# Altium schematic sheet MCU_Interface.SchDoc(MCU_Interface)
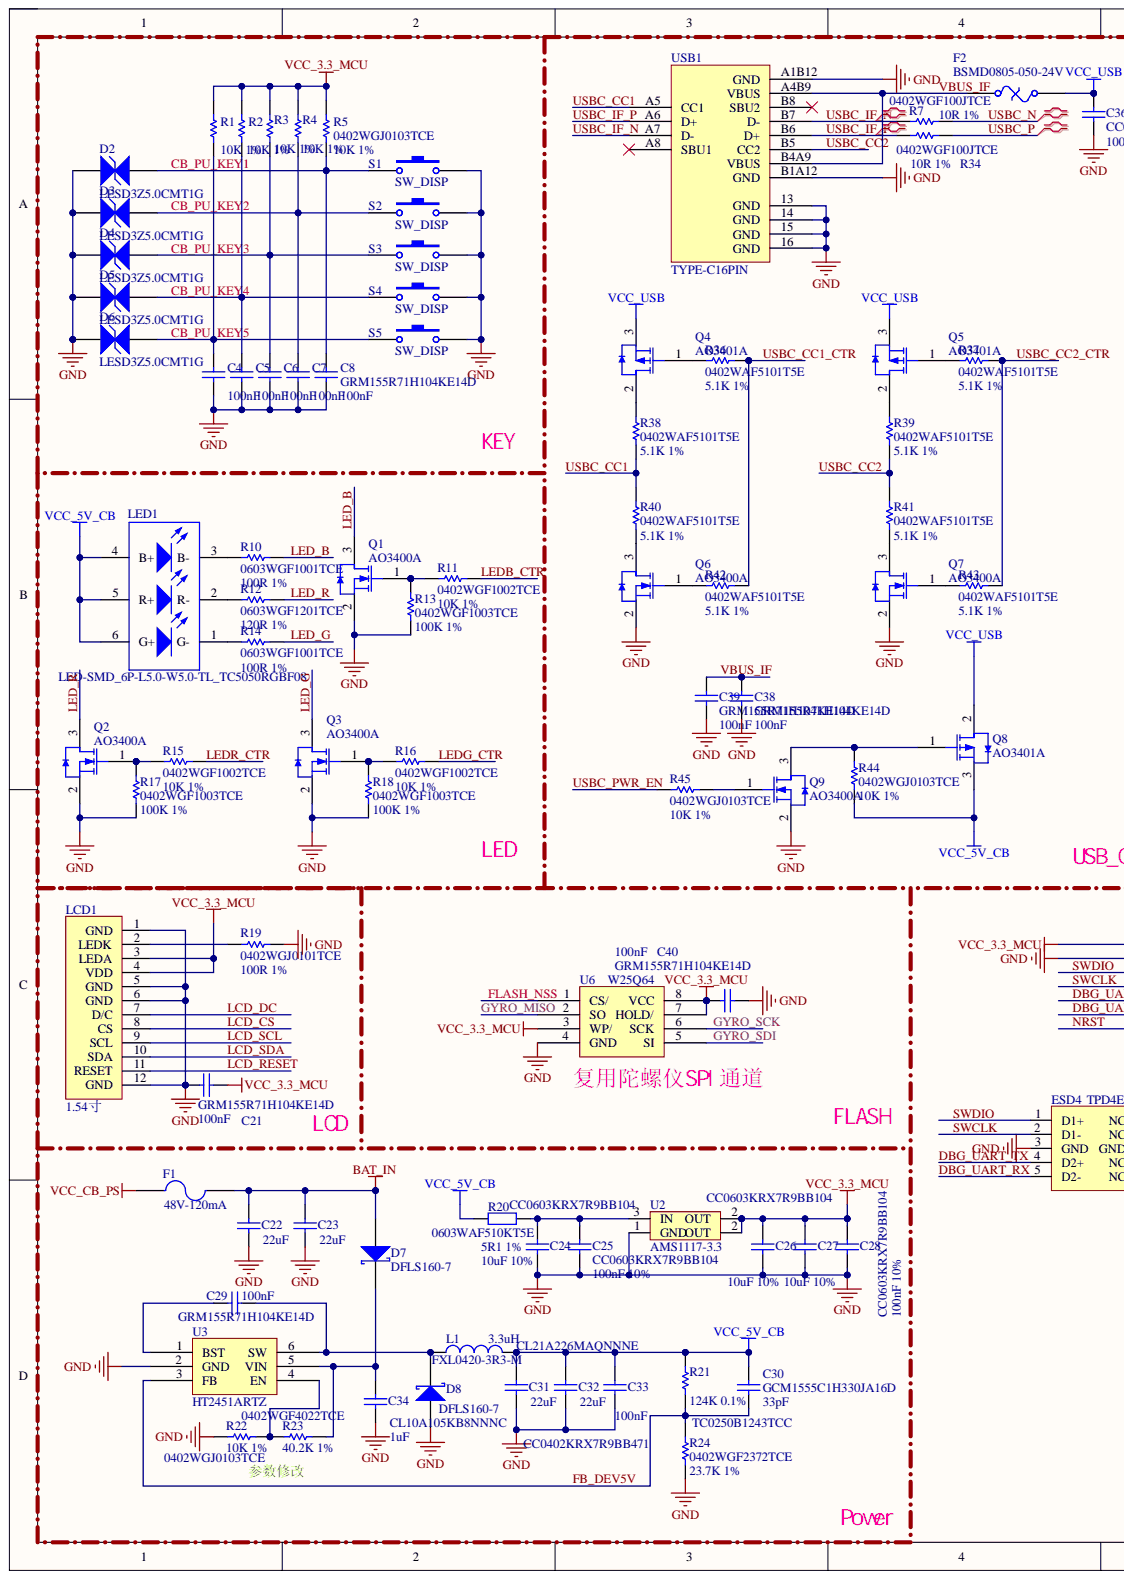
[diagram p0: MCU_Interface.SchDoc, left]
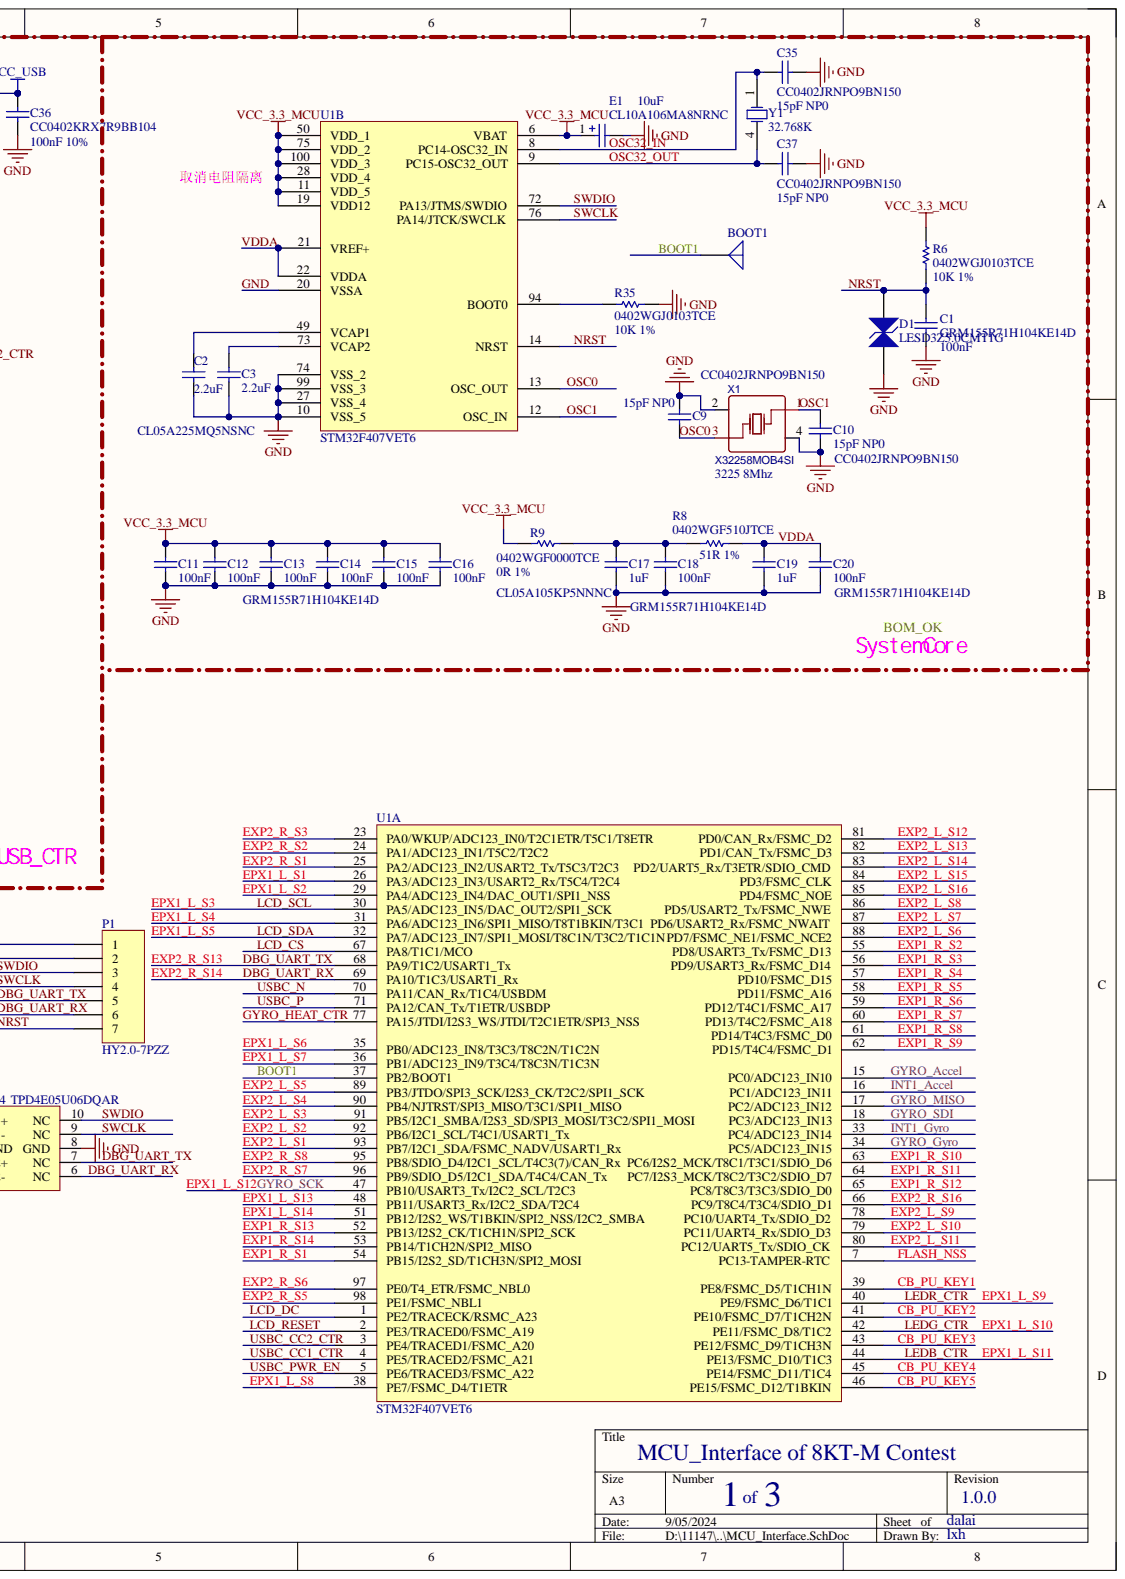
[diagram p1: MCU_Interface.SchDoc, right]

## Parts
BOOT1  Testpoint  [TP_1*1]  (value not drawn)
C1  GRM155R71H104KE14D  value 100nF  [0402_C_3D]
C2  CL05A225MQ5NSNC  value 2.2uF  [0402_C_3D]
C3  CL05A225MQ5NSNC  value 2.2uF  [0402_C_3D]
C4  GRM155R71H104KE14D  value 100nF  [0402_C_3D]
C5  GRM155R71H104KE14D  value 100nF  [0402_C_3D]
C6  GRM155R71H104KE14D  value 100nF  [0402_C_3D]
C7  GRM155R71H104KE14D  value 100nF  [0402_C_3D]
C8  GRM155R71H104KE14D  value 100nF  [0402_C_3D]
C9  CC0402JRNPO9BN150  value 15pF NP0  [0402_C_3D]
C10  CC0402JRNPO9BN150  value 15pF NP0  [0402_C_3D]
C11  GRM155R71H104KE14D  value 100nF  [0402_C_3D]
C12  GRM155R71H104KE14D  value 100nF  [0402_C_3D]
C13  GRM155R71H104KE14D  value 100nF  [0402_C_3D]
C14  GRM155R71H104KE14D  value 100nF  [0402_C_3D]
C15  GRM155R71H104KE14D  value 100nF  [0402_C_3D]
C16  GRM155R71H104KE14D  value 100nF  [0402_C_3D]
C17  CL05A105KP5NNNC  value 1uF  [0402_C_3D]
C18  GRM155R71H104KE14D  value 100nF  [0402_C_3D]
C19  CL05A105KP5NNNC  value 1uF  [0402_C_3D]
C20  GRM155R71H104KE14D  value 100nF  [0402_C_3D]
C21  GRM155R71H104KE14D  value 100nF  [0402_C_3D]
C22  CL21A226MAQNNNE  value 22uF  [0805_C_3D]
C23  CL21A226MAQNNNE  value 22uF  [0805_C_3D]
C24  CC0603KRX7R9BB104  value 10uF 10%  [0603_C_3D]
C25  CC0603KRX7R9BB104  value 100nF 10%  [0603_C_3D]
C26  CC0603KRX7R9BB104  value 10uF 10%  [0603_C_3D]
C27  CC0603KRX7R9BB104  value 10uF 10%  [0603_C_3D]
C28  CC0603KRX7R9BB104  value 100nF 10%  [0603_C_3D]
C29  GRM155R71H104KE14D  value 100nF  [0603_C_3D]
C30  GCM1555C1H330JA16D  value 33pF  [0402_C_3D]
C31  CL21A226MAQNNNE  value 22uF  [0805_C_3D]
C32  CL21A226MAQNNNE  value 22uF  [0805_C_3D]
C33  CC0402KRX7R9BB471  value 100nF  [0603_C_3D]
C34  CL10A105KB8NNNC  value 1uF  [0603_C_3D]
C35  CC0402JRNPO9BN150  value 15pF NP0  [0402_C_3D]
C36  CC0402KRX7R9BB104  value 100nF 10%  [0402_C_3D]
C37  CC0402JRNPO9BN150  value 15pF NP0  [0402_C_3D]
C38  GRM155R71H104KE14D  value 100nF  [0402_C_3D]
C39  GRM155R71H104KE14D  value 100nF  [0402_C_3D]
C40  GRM155R71H104KE14D  value 100nF  [0402_C_3D]
D1  LESD3Z5.0CMT1G  [SOD-323_L1.8-W1.3]
D2  LESD3Z5.0CMT1G  [SOD-323_L1.8-W1.3]
D3  LESD3Z5.0CMT1G  [SOD-323_L1.8-W1.3]
D4  LESD3Z5.0CMT1G  [SOD-323_L1.8-W1.3]
D5  LESD3Z5.0CMT1G  [SOD-323_L1.8-W1.3]
D6  LESD3Z5.0CMT1G  [SOD-323_L1.8-W1.3]
D7  DFLS160-7  [SOD-123_L2.8-W1.8]
D8  DFLS160-7  [SOD-123_L2.8-W1.8]
E1  CL10A106MA8NRNC  value 10uF  [0603_C_3D]
ESD4  TPD4E05U06DQAR  [USON-10_L2.5-W1.0-P0.50-BL]
F1  48V-120mA  [1206_R]
F2  BSMD0805-050-24V  [0805_R_3D]
L1  FXL0420-3R3-M  value 3.3uH  [IND-SMD_L7.0-W6.6]
LCD1  1.54寸  [1.54" OBE_IPS LCD]
LED1  LED-SMD_6P-L5.0-W5.0-TL_TC5050RGBF08  [LED-SMD_6P-L5.0-W5.0-TL_TC5050RGBF08]
P1  HY2.0-7PZZ  [CONN-TH_7P-P2.00_ZX-HY2.0-7PZZ]
Q1  AO3400A  [SOT-23-3D]
Q2  AO3400A  [SOT-23-3D]
Q3  AO3400A  [SOT-23-3D]
Q4  AO3401A  [SOT-23-3D]
Q5  AO3401A  [SOT-23-3D]
Q6  AO3400A  [SOT-23-3D]
Q7  AO3400A  [SOT-23-3D]
Q8  AO3401A  [SOT-23-3D]
Q9  AO3400A  [SOT-23-3D]
R1  0402WGJ0103TCE  value 10K 1%  [0402_R_3D]
R2  0402WGJ0103TCE  value 10K 1%  [0402_R_3D]
R3  0402WGJ0103TCE  value 10K 1%  [0402_R_3D]
R4  0402WGJ0103TCE  value 10K 1%  [0402_R_3D]
R5  0402WGJ0103TCE  value 10K 1%  [0402_R_3D]
R6  0402WGJ0103TCE  value 10K 1%  [0402_R_3D]
R7  0402WGF100JTCE  value 10R 1%  [0402_R_3D]
R8  0402WGF510JTCE  value 51R 1%  [0402_R_3D]
R9  0402WGF0000TCE  value 0R 1%  [0402_R_3D]
R10  0603WGF1001TCE  value 100R 1%  [0603_R_3D]
R11  0402WGF1002TCE  value 10K 1%  [0402_R_3D]
R12  0603WGF1201TCE  value 120R 1%  [0603_R_3D]
R13  0402WGF1003TCE  value 100K 1%  [0402_R_3D]
R14  0603WGF1001TCE  value 100R 1%  [0603_R_3D]
R15  0402WGF1002TCE  value 10K 1%  [0402_R_3D]
R16  0402WGF1002TCE  value 10K 1%  [0402_R_3D]
R17  0402WGF1003TCE  value 100K 1%  [0402_R_3D]
R18  0402WGF1003TCE  value 100K 1%  [0402_R_3D]
R19  0402WGJ0101TCE  value 100R 1%  [0402_R_3D]
R20  0603WAF510KT5E  value 5R1 1%  [0603_R_3D]
R21  TC0250B1243TCC  value 124K 0.1%  [0402_R_3D]
R22  0402WGJ0103TCE  value 10K 1%  [0402_R_3D]
R23  0402WGF4022TCE  value 40.2K 1%  [0402_R_3D]
R24  0402WGF2372TCE  value 23.7K 1%  [0402_R_3D]
R34  0402WGF100JTCE  value 10R 1%  [0402_R_3D]
R35  0402WGJ0103TCE  value 10K 1%  [0402_R_3D]
R36  0402WAF5101T5E  value 5.1K 1%  [0402_R_3D]
R37  0402WAF5101T5E  value 5.1K 1%  [0402_R_3D]
R38  0402WAF5101T5E  value 5.1K 1%  [0402_R_3D]
R39  0402WAF5101T5E  value 5.1K 1%  [0402_R_3D]
R40  0402WAF5101T5E  value 5.1K 1%  [0402_R_3D]
R41  0402WAF5101T5E  value 5.1K 1%  [0402_R_3D]
R42  0402WAF5101T5E  value 5.1K 1%  [0402_R_3D]
R43  0402WAF5101T5E  value 5.1K 1%  [0402_R_3D]
R44  0402WGJ0103TCE  value 10K 1%  [0402_R_3D]
R45  0402WGJ0103TCE  value 10K 1%  [0402_R_3D]
S1  SW_DISP  [KEY-SMD_4P-L4.2-W3.2-P2.20-LS4.6]
S2  SW_DISP  [KEY-SMD_4P-L4.2-W3.2-P2.20-LS4.6]
S3  SW_DISP  [KEY-SMD_4P-L4.2-W3.2-P2.20-LS4.6]
S4  SW_DISP  [KEY-SMD_4P-L4.2-W3.2-P2.20-LS4.6]
S5  SW_DISP  [KEY-SMD_4P-L4.2-W3.2-P2.20-LS4.6]
U1  STM32F407VET6  [LQFP-100_L14.0-W14.0-P0.50-LS16.0-BL]  (parts A,B)
U2  AMS1117-3.3  [SOT-89-3_L4.5-W2.5-P1.50_3D]
U3  HT2451ARTZ  [SOT-23-6_L2.9-W1.6-P0.95_3D]
U6  W25Q64  [WSOP-8_L5.3-W5.3-P1.27-LS8.0-BL]
USB1  TYPE-C16PIN  [USB-C-SMD_TYPEC-304J-BCP16]
X1  X32258MOB4SI  value 3225 8Mhz  [SMD-3225_3D]
Y1  32.768K  [OSC-SMD_4P-L8.7-W3.7-BL]

## Nets
BAT_IN: C22.1 C23.1 D7.1 F1.2
VCC_3.3_MCU: C11.1 C12.1 C13.1 C14.1 C15.1 C16.1 C21.1 C26.1 C27.1 C28.1 C40.2 E1.1 LCD1.3(LEDA) LCD1.4(VDD) P1.1 R1.2 R2.2 R3.1 R4.1 R5.2 R6.2 R9.2 U1.6(VBAT) U1.11(VDD_5) U1.19(VDD12) U1.28(VDD_4) U1.50(VDD_1) U1.75(VDD_2) U1.100(VDD_3) U2.2 U6.3(WP/) U6.7(HOLD/) U6.8(VCC)
VCC_5V_CB: C30.2 C31.1 C32.1 C33.2 L1.2 LED1.4(B+) LED1.5(R+) LED1.6(G+) Q8.3 R20.1 R21.2 R44.2
VCC_CB_PS: F1.1
VCC_USB: C36.2 F2.2 Q4.3 Q5.3 Q8.2
BOOT1: BOOT1.1 U1.37(PB2/BOOT1)
CB_PU_KEY1: C8.2 D2.1 R5.1 S1.1 U1.39(PE8/FSMC_D5/T1CH1N)
CB_PU_KEY2: C7.2 D3.1 R4.2 S2.1 U1.41(PE10/FSMC_D7/T1CH2N)
CB_PU_KEY3: C6.2 D4.1 R3.2 S3.1 U1.43(PE12/FSMC_D9/T1CH3N)
CB_PU_KEY4: C5.2 D5.1 R2.1 S4.1 U1.45(PE14/FSMC_D11/T1C4)
CB_PU_KEY5: C4.2 D6.1 R1.1 S5.1 U1.46(PE15/FSMC_D12/T1BKIN)
DBG_UART_RX: ESD4.5(D2-) ESD4.6(NC) P1.6 U1.69(PA10/T1C3/USART1_Rx)
DBG_UART_TX: ESD4.4(D2+) ESD4.7(NC) P1.5 U1.68(PA9/T1C2/USART1_Tx)
EPX1_L_S1: U1.26(PA3/ADC123_IN3/USART2_Rx/T5C4/T2C4)
EPX1_L_S2: U1.29(PA4/ADC123_IN4/DAC_OUT1/SPI1_NSS)
EPX1_L_S3: LCD1.9(SCL) U1.30(PA5/ADC123_IN5/DAC_OUT2/SPI1_SCK)
EPX1_L_S4: U1.31(PA6/ADC123_IN6/SPI1_MISO/T8T1BKIN/T3C1)
EPX1_L_S5: LCD1.10(SDA) U1.32(PA7/ADC123_IN7/SPI1_MOSI/T8C1N/T3C2/T1C1N)
EPX1_L_S6: U1.35(PB0/ADC123_IN8/T3C3/T8C2N/T1C2N)
EPX1_L_S7: U1.36(PB1/ADC123_IN9/T3C4/T8C3N/T1C3N)
EPX1_L_S8: U1.38(PE7/FSMC_D4/T1ETR)
EPX1_L_S9: R15.2 U1.40(PE9/FSMC_D6/T1C1)
EPX1_L_S10: R16.2 U1.42(PE11/FSMC_D8/T1C2)
EPX1_L_S11: R11.2 U1.44(PE13/FSMC_D10/T1C3)
EPX1_L_S12: U1.47(PB10/USART3_Tx/I2C2_SCL/T2C3) U6.6(SCK)
EPX1_L_S13: U1.48(PB11/USART3_Rx/I2C2_SDA/T2C4)
EPX1_L_S14: U1.51(PB12/I2S2_WS/T1BKIN/SPI2_NSS/I2C2_SMBA)
EXP1_R_S1: U1.54(PB15/I2S2_SD/T1CH3N/SPI2_MOSI)
EXP1_R_S2: U1.55(PD8/USART3_Tx/FSMC_D13)
EXP1_R_S3: U1.56(PD9/USART3_Rx/FSMC_D14)
EXP1_R_S4: U1.57(PD10/FSMC_D15)
EXP1_R_S5: U1.58(PD11/FSMC_A16)
EXP1_R_S6: U1.59(PD12/T4C1/FSMC_A17)
EXP1_R_S7: U1.60(PD13/T4C2/FSMC_A18)
EXP1_R_S8: U1.61(PD14/T4C3/FSMC_D0)
EXP1_R_S9: U1.62(PD15/T4C4/FSMC_D1)
EXP1_R_S10: U1.63(PC6/I2S2_MCK/T8C1/T3C1/SDIO_D6)
EXP1_R_S11: U1.64(PC7/I2S3_MCK/T8C2/T3C2/SDIO_D7)
EXP1_R_S12: U1.65(PC8/T8C3/T3C3/SDIO_D0)
EXP1_R_S13: U1.52(PB13/I2S2_CK/T1CH1N/SPI2_SCK)
EXP1_R_S14: U1.53(PB14/T1CH2N/SPI2_MISO)
EXP2_L_S1: U1.93(PB7/I2C1_SDA/FSMC_NADV/USART1_Rx)
EXP2_L_S2: U1.92(PB6/I2C1_SCL/T4C1/USART1_Tx)
EXP2_L_S3: U1.91(PB5/I2C1_SMBA/I2S3_SD/SPI3_MOSI/T3C2/SPI1_MOSI)
EXP2_L_S4: U1.90(PB4/NJTRST/SPI3_MISO/T3C1/SPI1_MISO)
EXP2_L_S5: U1.89(PB3/JTDO/SPI3_SCK/I2S3_CK/T2C2/SPI1_SCK)
EXP2_L_S6: U1.88(PD7/FSMC_NE1/FSMC_NCE2)
EXP2_L_S7: U1.87(PD6/USART2_Rx/FSMC_NWAIT)
EXP2_L_S8: U1.86(PD5/USART2_Tx/FSMC_NWE)
EXP2_L_S9: U1.78(PC10/UART4_Tx/SDIO_D2)
EXP2_L_S10: U1.79(PC11/UART4_Rx/SDIO_D3)
EXP2_L_S11: U1.80(PC12/UART5_Tx/SDIO_CK)
EXP2_L_S12: U1.81(PD0/CAN_Rx/FSMC_D2)
EXP2_L_S13: U1.82(PD1/CAN_Tx/FSMC_D3)
EXP2_L_S14: U1.83(PD2/UART5_Rx/T3ETR/SDIO_CMD)
EXP2_L_S15: U1.84(PD3/FSMC_CLK)
EXP2_L_S16: U1.85(PD4/FSMC_NOE)
EXP2_R_S1: U1.25(PA2/ADC123_IN2/USART2_Tx/T5C3/T2C3)
EXP2_R_S2: U1.24(PA1/ADC123_IN1/T5C2/T2C2)
EXP2_R_S3: U1.23(PA0/WKUP/ADC123_IN0/T2C1ETR/T5C1/T8ETR)
EXP2_R_S5: U1.98(PE1/FSMC_NBL1)
EXP2_R_S6: U1.97(PE0/T4_ETR/FSMC_NBL0)
EXP2_R_S7: U1.96(PB9/SDIO_D5/I2C1_SDA/T4C4/CAN_Tx)
EXP2_R_S8: U1.95(PB8/SDIO_D4/I2C1_SCL/T4C3(7)/CAN_Rx)
EXP2_R_S16: U1.66(PC9/T8C4/T3C4/SDIO_D1)
FB_DEV5V: C30.1 R21.1 R24.2 U3.3(FB)
FLASH_NSS: U1.7(PC13-TAMPER-RTC) U6.1(CS/)
GND: C1.1 C2.1 C3.1 C4.1 C5.1 C6.1 C7.1 C8.1 C9.1 C10.2 C11.2 C12.2 C13.2 C14.2 C15.2 C16.2 C17.1 C18.2 C19.2 C20.2 C21.2 C22.2 C23.2 C24.2 C25.2 C26.2 C27.2 C28.2 C31.2 C32.2 C33.1 C34.2 C35.2 C36.1 C37.2 C38.1 C39.1 C40.1 D1.2 D2.2 D3.2 D4.2 D5.2 D6.2 D8.1 E1.2 ESD4.3(GND) ESD4.8(GND) LCD1.1(GND) LCD1.5(GND) LCD1.6(GND) LCD1.12(GND) P1.2 Q1.2 Q2.2 Q3.2 Q6.2 Q7.2 Q9.2 R13.2 R17.2 R18.2 R19.1 R22.2 R24.1 R35.1 S1.3 S2.3 S3.3 S4.3 S5.3 U1.10(VSS_5) U1.20(VSSA) U1.27(VSS_4) U1.74(VSS_2) U1.99(VSS_3) U2.1 U3.2(GND) U6.4(GND) USB1.13(GND) USB1.14(GND) USB1.15(GND) USB1.16(GND) USB1.A1B12(GND) USB1.B1A12(GND) X1.2 X1.4
GYRO_Accel: U1.15(PC0/ADC123_IN10)
GYRO_Gyro: U1.34(PC5/ADC123_IN15)
GYRO_HEAT_CTR: U1.77(PA15/JTDI/I2S3_WS/JTDI/T2C1ETR/SPI3_NSS)
GYRO_MISO: U1.17(PC2/ADC123_IN12) U6.2(SO)
GYRO_SDI: U1.18(PC3/ADC123_IN13) U6.5(SI)
INT1_Accel: U1.16(PC1/ADC123_IN11)
INT1_Gyro: U1.33(PC4/ADC123_IN14)
LCD_CS: LCD1.8(CS) U1.67(PA8/T1C1/MCO)
LCD_DC: LCD1.7(D/C) U1.1(PE2/TRACECK/RSMC_A23)
LCD_RESET: LCD1.11(RESET) U1.2(PE3/TRACED0/FSMC_A19)
LED_B: Q1.3 R10.2
LED_G: Q3.3 R14.2
LED_R: Q2.3 R12.2
NRST: C1.2 D1.1 P1.7 R6.1 U1.14(NRST)
OSC0: C9.2 U1.13(OSC_OUT) X1.3
OSC1: C10.1 U1.12(OSC_IN) X1.1
OSC32_IN: C35.1 U1.8(PC14-OSC32_IN) Y1.1
OSC32_OUT: C37.1 U1.9(PC15-OSC32_OUT) Y1.4
SWCLK: ESD4.2(D1-) ESD4.9(NC) P1.4 U1.76(PA14/JTCK/SWCLK)
SWDIO: ESD4.1(D1+) ESD4.10(NC) P1.3 U1.72(PA13/JTMS/SWDIO)
USBC_CC1: R38.1 R40.2 USB1.A5(CC1)
USBC_CC1_CTR: R36.1 R42.1 U1.4(PE5/TRACED2/FSMC_A21)
USBC_CC2: R39.1 R41.2 USB1.B5(CC2)
USBC_CC2_CTR: R37.1 R43.1 U1.3(PE4/TRACED1/FSMC_A20)
USBC_IF_N: R7.2 USB1.A7(D-) USB1.B7(D-)
USBC_IF_P: R34.2 USB1.A6(D+) USB1.B6(D+)
USBC_N: R7.1 U1.70(PA11/CAN_Rx/T1C4/USBDM)
USBC_P: R34.1 U1.71(PA12/CAN_Tx/T1ETR/USBDP)
USBC_PWR_EN: R45.1 U1.5(PE6/TRACED3/FSMC_A22)
VBUS_IF: C38.2 C39.2 F2.1 USB1.A4B9(VBUS) USB1.B4A9(VBUS)
VDDA: C19.1 C20.1 R8.1 U1.21(VREF+) U1.22(VDDA)
NetC2_2: C2.2 U1.49(VCAP1)
NetC3_2: C3.2 U1.73(VCAP2)
NetC17_2: C17.2 C18.1 R8.2 R9.1
NetC24_1: C24.1 C25.1 R20.2 U2.3
NetC29_1: C29.1 D8.2 L1.1 U3.6(SW)
NetC29_2: C29.2 U3.1(BST)
NetC34_1: C34.1 D7.2 R23.2 U3.5(VIN)
NetLCD1_2: LCD1.2(LEDK) R19.2
NetLED1_1: LED1.1(G-) R14.1
NetLED1_2: LED1.2(R-) R12.1
NetLED1_3: LED1.3(B-) R10.1
NetQ1_1: Q1.1 R11.1 R13.1
NetQ2_1: Q2.1 R15.1 R17.1
NetQ3_1: Q3.1 R16.1 R18.1
NetQ4_1: Q4.1 R36.2
NetQ4_2: Q4.2 R38.2
NetQ5_1: Q5.1 R37.2
NetQ5_2: Q5.2 R39.2
NetQ6_1: Q6.1 R42.2
NetQ6_3: Q6.3 R40.1
NetQ7_1: Q7.1 R43.2
NetQ7_3: Q7.3 R41.1
NetQ8_1: Q8.1 Q9.3 R44.1
NetQ9_1: Q9.1 R45.2
NetR22_1: R22.1 R23.1 U3.4(EN)
NetR35_2: R35.2 U1.94(BOOT0)

Not connected: USB1 A8(SBU1) B8(SBU2)

## Library data of the parts
BOOT1 = Antenna: Test Point; Component Kind: Standard; Library Name: Miscellaneous Devices.IntLib; Package: Testpoint
C1-C24 C26-C34 C36-C40 = Cap: Capacitor; Component Kind: Standard
  C22 C23 C30-C34: LatestRevisionDate: 17-Jul-2002; LatestRevisionNote: Re-released for DXP Platform.; Library Name: Miscellaneous Devices.IntLib; PackageDescription: Radial Cap, Thru-Hole; 2 Leads; 0.3 in Pin Spacing; PackageReference: RAD-0.3; Published: 8-Jun-2000; Signal Integrity: Cap; Simulation: CAP
  C24 C26-C29 C36: LatestRevisionDate: 17-Jul-2002; LatestRevisionNote: Re-released for DXP Platform.; Library Name: Miscellaneous Devices.IntLib; PackageDescription: Radial Cap, Thru-Hole; 2 Leads; 0.3 in Pin Spacing; PackageReference: RAD-0.3; Published: 8-Jun-2000
  C1-C21 C37-C40: Library Name: Fszhang.IntLib
  C22-C24 C26-C34 C36: Publisher: Altium Limited
C25 = Cap: Capacitor; Value: 100nF 10%; Component Kind: Standard; LatestRevisionDate: 17-Jul-2002; LatestRevisionNote: Re-released for DXP Platform.; Library Name: Miscellaneous Devices.IntLib; PackageDescription: Radial Cap, Thru-Hole; 2 Leads; 0.3 in Pin Spacing; PackageReference: RAD-0.3; Published: 8-Jun-2000; Publisher: Altium Limited
C35 = Cap: Capacitor; Value: 15pF NP0; Component Kind: Standard; Library Name: Fszhang.IntLib
D1-D6 = ESD-0603: TVS; Component Kind: Standard; Package: SOD-323_L1.8-W1.3; Value: LESD3Z5.0CMT1G; Library Name: jfj_Schlib.SchLib
D7 D8 = D Schottky: Schottky Diode; Code_JEDEC: DO-214-AA; Component Kind: Standard; LatestRevisionDate: 17-Jul-2002; LatestRevisionNote: Re-released for DXP Platform.; Library Name: Miscellaneous Devices.IntLib; PackageDescription: DO-214-AA/SMB; 2 C-Bend Leads; Body 5.3 x 3.6 mm, inc. leads (LxW); PackageReference: SMB; Published: 8-Jun-2000; Signal Integrity: D Schottky; Simulation: SKYDIODE; Publisher: Altium Limited
E1 = Cap Pol1: Capacitor; Component Kind: Standard; Revision: July-2002: Re-released for DXP Platform.
ESD4 = TPD4E05U06DQAR: ESD for SSUSB; Component Kind: Standard; Library Name: My package.SchLib
F1 = Fuse 2: 保险丝; Value: 250V/0.12A  RL250-120; Component Kind: Standard; LatestRevisionDate: 17-Jul-2002; LatestRevisionNote: Re-released for DXP Platform.; PackageReference: PIN-W2/E2.8; Published: 8-Jun-2000; Library Name: hai_IntLib_1.IntLib; Publisher: Altium Limited
F2 = Fuse Thermal: Component Kind: Standard; Description: BSMD0805-050-24V; LatestRevisionDate: 17-Jul-2002; LatestRevisionNote: Re-released for DXP Platform.; Library Name: Miscellaneous Devices.IntLib; PackageDescription: Thru-Hole; 2 Leads; PackageReference: PIN-W2/E2.8; Published: 24-Mar-1999; Publisher: Altium Limited
L1 = Inductor: Code_IEC: 1005; Code_JEITA: 0402; Component Kind: Standard; Description: Inductor; LatestRevisionDate: 29-May-2009; LatestRevisionNote: IPC-7351 Footprint Added.; Library Name: Miscellaneous Devices.IntLib; PackageDescription: Chip Inductor; PackageReference: 0402-A; Published: 8-Jun-2000; Signal Integrity: Inductor; Simulation: INDUCTOR; Publisher: Altium Limited
LCD1 = 1.54寸240*240高清ips显示屏st7789驱动高清液晶: Component Kind: Standard; Library Name: LXD.SchLib
P1 = Header 7: Component Kind: Standard; Description: HY2.0-7PZZ; LatestRevisionDate: 17-Jul-2002; LatestRevisionNote: Re-released for DXP Platform.; Library Name: Miscellaneous Connectors.IntLib; Signal Integrity: Connector; Publisher: Altium Limited
Q1-Q3 Q6 Q7 Q9 = AO3400A N道沟 X0YV1T SOT-23: MOS(场效应管); Component Kind: Standard; Library Name: JLC.IntLib; Package: SOT-23
Q4 Q5 Q8 = AO3401A P道沟 X13A15 SOT-23: MOS(场效应管); Component Kind: Standard; ComponentLink1Description: 供应商链接; ComponentLink1URL: http://www.szlcsc.com/product/details_15801.html; Library Name: JLC.IntLib; Package: SOT-23; Supplier: LC; SuppliersPartNumber: C15127
R1 R11 R15 R16 R44 = Res1: Resistor; Value: 10K 1%; Component Kind: Standard; Library Name: Miscellaneous Devices.IntLib
R2-R9 R13 R17-R19 R22-R24 R34-R36 R38 R39 R45 = Res1: Resistor; Component Kind: Standard; Library Name: Miscellaneous Devices.IntLib
  R24: LatestRevisionDate: 17-Jul-2002; LatestRevisionNote: Re-released for DXP Platform.; PackageDescription: Axial Device, Thru-Hole; 2 Leads; 0.3 in Pin Spacing; PackageReference: AXIAL-0.3; Published: 8-Jun-2000; Signal Integrity: Res; Simulation: RESISTOR; Publisher: Altium Limited
R10 R14 = Res1: Resistor; Value: 100R 1%; Component Kind: Standard; Library Name: Miscellaneous Devices.IntLib
R12 = Res1: Resistor; Value: 120R 1%; Component Kind: Standard; Library Name: Miscellaneous Devices.IntLib
R20 = Res2: Resistor; Value: 5R1 1%; Component Kind: Standard; LatestRevisionDate: 17-Jul-2002; LatestRevisionNote: Re-released for DXP Platform.; Library Name: Miscellaneous Devices.IntLib; PackageDescription: Axial Device, Thru-Hole; 2 Leads; 0.4 in Pin Spacing; PackageReference: AXIAL-0.4; Published: 8-Jun-2000; Signal Integrity: Res; Simulation: RESISTOR; Publisher: Altium Limited
R21 = Res1: Resistor; Value: 124K 0.1%; Component Kind: Standard; LatestRevisionDate: 17-Jul-2002; LatestRevisionNote: Re-released for DXP Platform.; Library Name: Miscellaneous Devices.IntLib; PackageDescription: Axial Device, Thru-Hole; 2 Leads; 0.3 in Pin Spacing; PackageReference: AXIAL-0.3; Published: 8-Jun-2000; Signal Integrity: Res; Simulation: RESISTOR; Publisher: Altium Limited
R37 R40-R43 = Res1: Resistor; Value: 5.1K 1%; Component Kind: Standard; Library Name: Miscellaneous Devices.IntLib
S1-S5 = SW-PB: Switch; Component Kind: Standard; LatestRevisionDate: 17-Jul-2002; LatestRevisionNote: Re-released for DXP Platform.; Library Name: Miscellaneous Devices.IntLib; PackageDescription: Switch; 2 Leads; PackageReference: SPST-2; Published: 24-Mar-1999; Publisher: Altium Limited
U1 = STM32F407V: MCU; Component Kind: Standard; Library Name: RM9250.2.SCHLIB
U2 = AMS1117: Component Kind: Standard; Library Name: WARSHIP.SCHLIB
U3 = MP2451: 36V, 2MHz, 0.6A step-down converter from MPS; Component Kind: Standard; Library Name: freescale_lib.SchLib
U6 = W25X10: Component Kind: Standard
X1 = 25MHz: HSE; Component Kind: Standard
Y1 = XTAL_1: Crystal Oscillator; Component Kind: Standard; LatestRevisionDate: 17-Jul-2002; LatestRevisionNote: Re-released for DXP Platform.; Library Name: STM32F407ZGT6.SCHLIB; Published: 8-Jun-2000; Simulation: XTAL; Publisher: Altium Limited
LED1 = LED-SMD_6P-L5.0-W5.0-TL_TC5050RGBF08: Component Kind: Standard; Library Name: My package.SchLib
USB1 = TYPE-C16PIN: Component Kind: Standard; Description: TYPE-C16PIN; Library Name: My package.SchLib; Package: TYPE-C 16PLC-H10.0
